# Altium schematic sheet Sheet1.SchDoc(Sheet1)
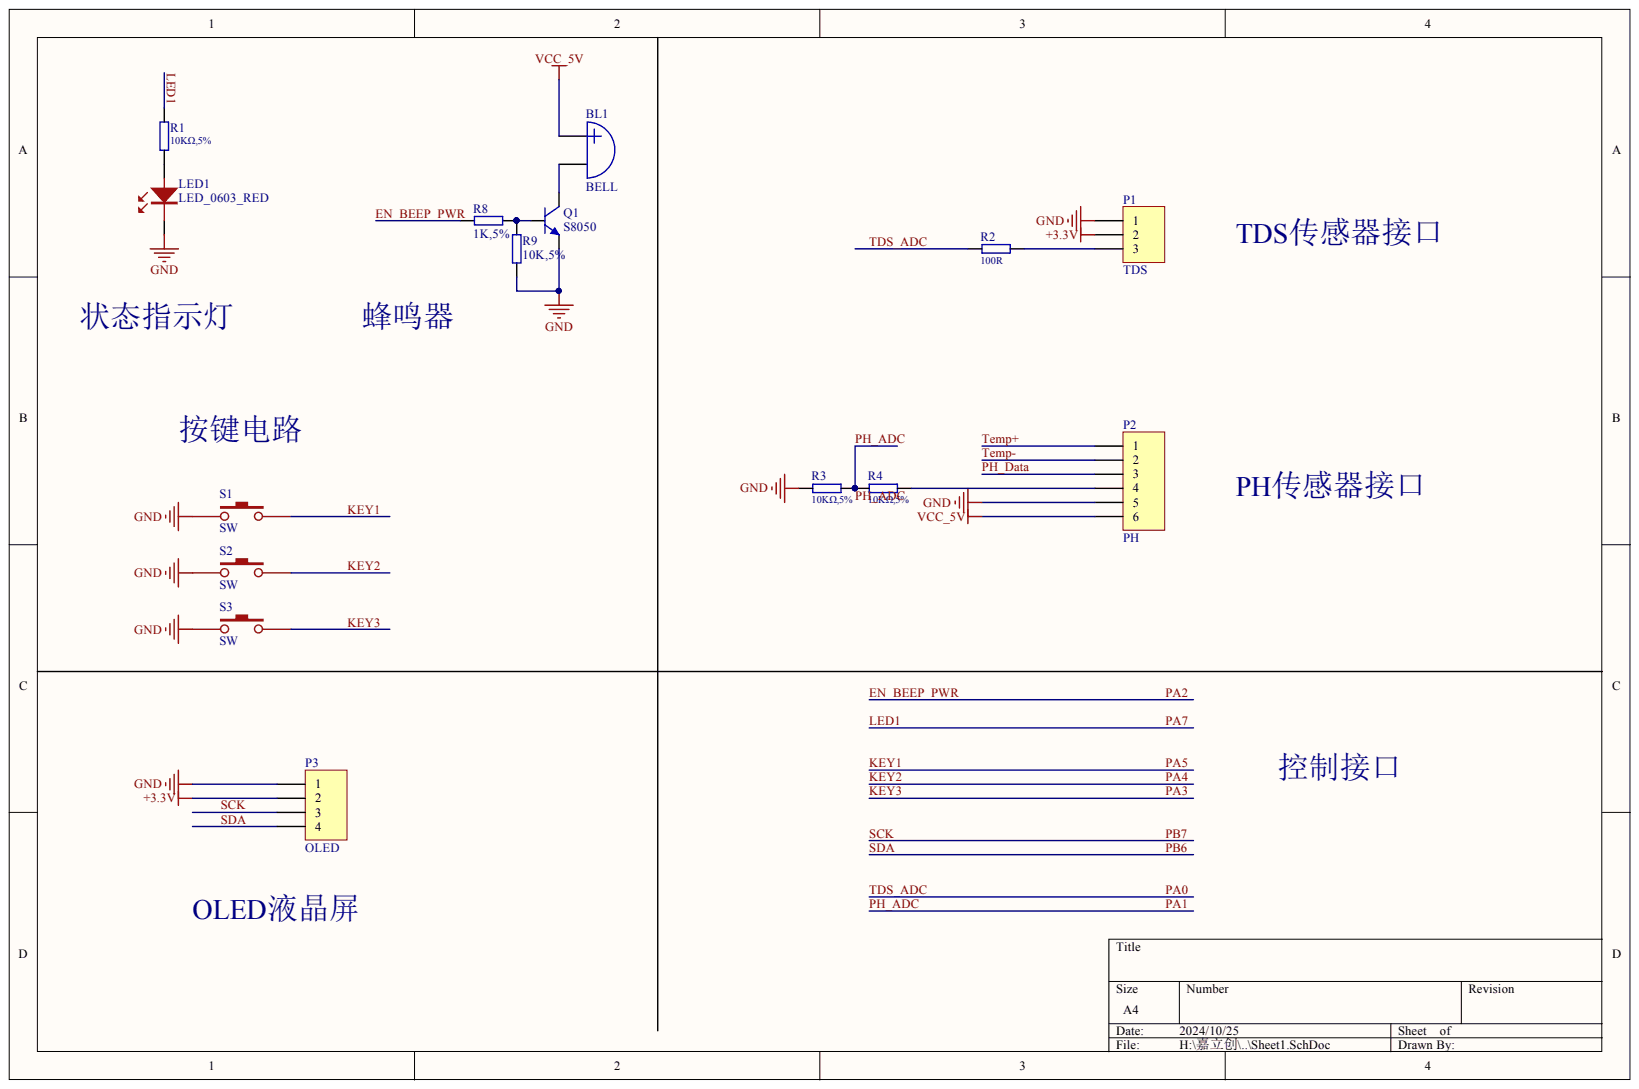
[diagram p0: Sheet1.SchDoc]

## Parts
BL1  BELL  [BELL]
LED1  LED_0603_RED  [LED-0603]
P1  TDS  [SIP03-2.5]
P2  PH  [SIP06-2.54]
P3  OLED  [OLED-TH_L27.8-W27.2-P2.54_C9900033791]
Q1  S8050  [SOT23-3]
R1  10KΩ,5%  [SMD]
R2  100R  [SMD]
R3  10KΩ,5%  [SMD]
R4  10KΩ,5%  [SMD]
R8  1K,5%  [RES_0603]
R9  10K,5%  [RES_0603]
S1  SW  [SW-SMD_4P-L6.2-W6.2-P4.50-LS9.0]
S2  SW  [SW-SMD_4P-L6.2-W6.2-P4.50-LS9.0]
S3  SW  [SW-SMD_4P-L6.2-W6.2-P4.50-LS9.0]

## Nets
+3.3V: P1.2 P3.2
GND: LED1.2 P1.1 P2.5 P3.1 Q1.2 R3.2 R9.1 S1.4 S2.4 S3.4
VCC_5V: BL1.1 P2.6
EN_BEEP_PWR: R8.1
KEY1: S1.2
KEY2: S2.2
KEY3: S3.2
LED1: R1.2
PA0: R2.2
PA1: R3.1 R4.2
PB6: P3.4
PB7: P3.3
PH_DATA: P2.3
TEMP+: P2.1
TEMP-: P2.2
NetBL1_2: BL1.2 Q1.3
NetLED1_1: LED1.1 R1.1
NetP1_3: P1.3 R2.1
NetP2_4: P2.4 R4.1
NetQ1_1: Q1.1 R8.2 R9.2

## Library data of the parts
LED1 = LED_R: Component Kind: Standard
P1 = Header 3: Header, 3-Pin; Component Kind: Standard; LatestRevisionDate: 17-Jul-2002; LatestRevisionNote: Re-released for DXP Platform.; Library Name: Miscellaneous Connectors.IntLib; Signal Integrity: Connector; Publisher: Altium Limited
P2 = Header 6: Header, 6-Pin; Component Kind: Standard; LatestRevisionDate: 17-Jul-2002; LatestRevisionNote: Re-released for DXP Platform.; Library Name: Miscellaneous Connectors.IntLib; Signal Integrity: Connector; Publisher: Altium Limited
P3 = Header 4: Header, 4-Pin; Component Kind: Standard; LatestRevisionDate: 17-Jul-2002; LatestRevisionNote: Re-released for DXP Platform.; Library Name: Miscellaneous Connectors.IntLib; Signal Integrity: Connector; Publisher: Altium Limited
Q1 = AUDION: Component Kind: Standard; Library Name: 我的元件库.IntLib
R1-R4 = Pro1B.tmp_R150_46: 贴片电阻，±5%; Electronic Manufacturer: YAGEO; Clearance: A; IC_ULT: NULL; IC Types: 贴片电阻; Component Kind: Standard; Unit Price: 0.03
R8 R9 = RES5: Component Kind: Standard
S1-S3 = SW: 轻触开关; Component Kind: Standard; Library Name: SRIE_SCH.SchLib
BL1 = BELL: Component Kind: Standard; Description: Bell; Library Name: UsrSch_Lib.lib
